# Altium schematic sheet S03_Power_ACDC_RevF.SchDoc
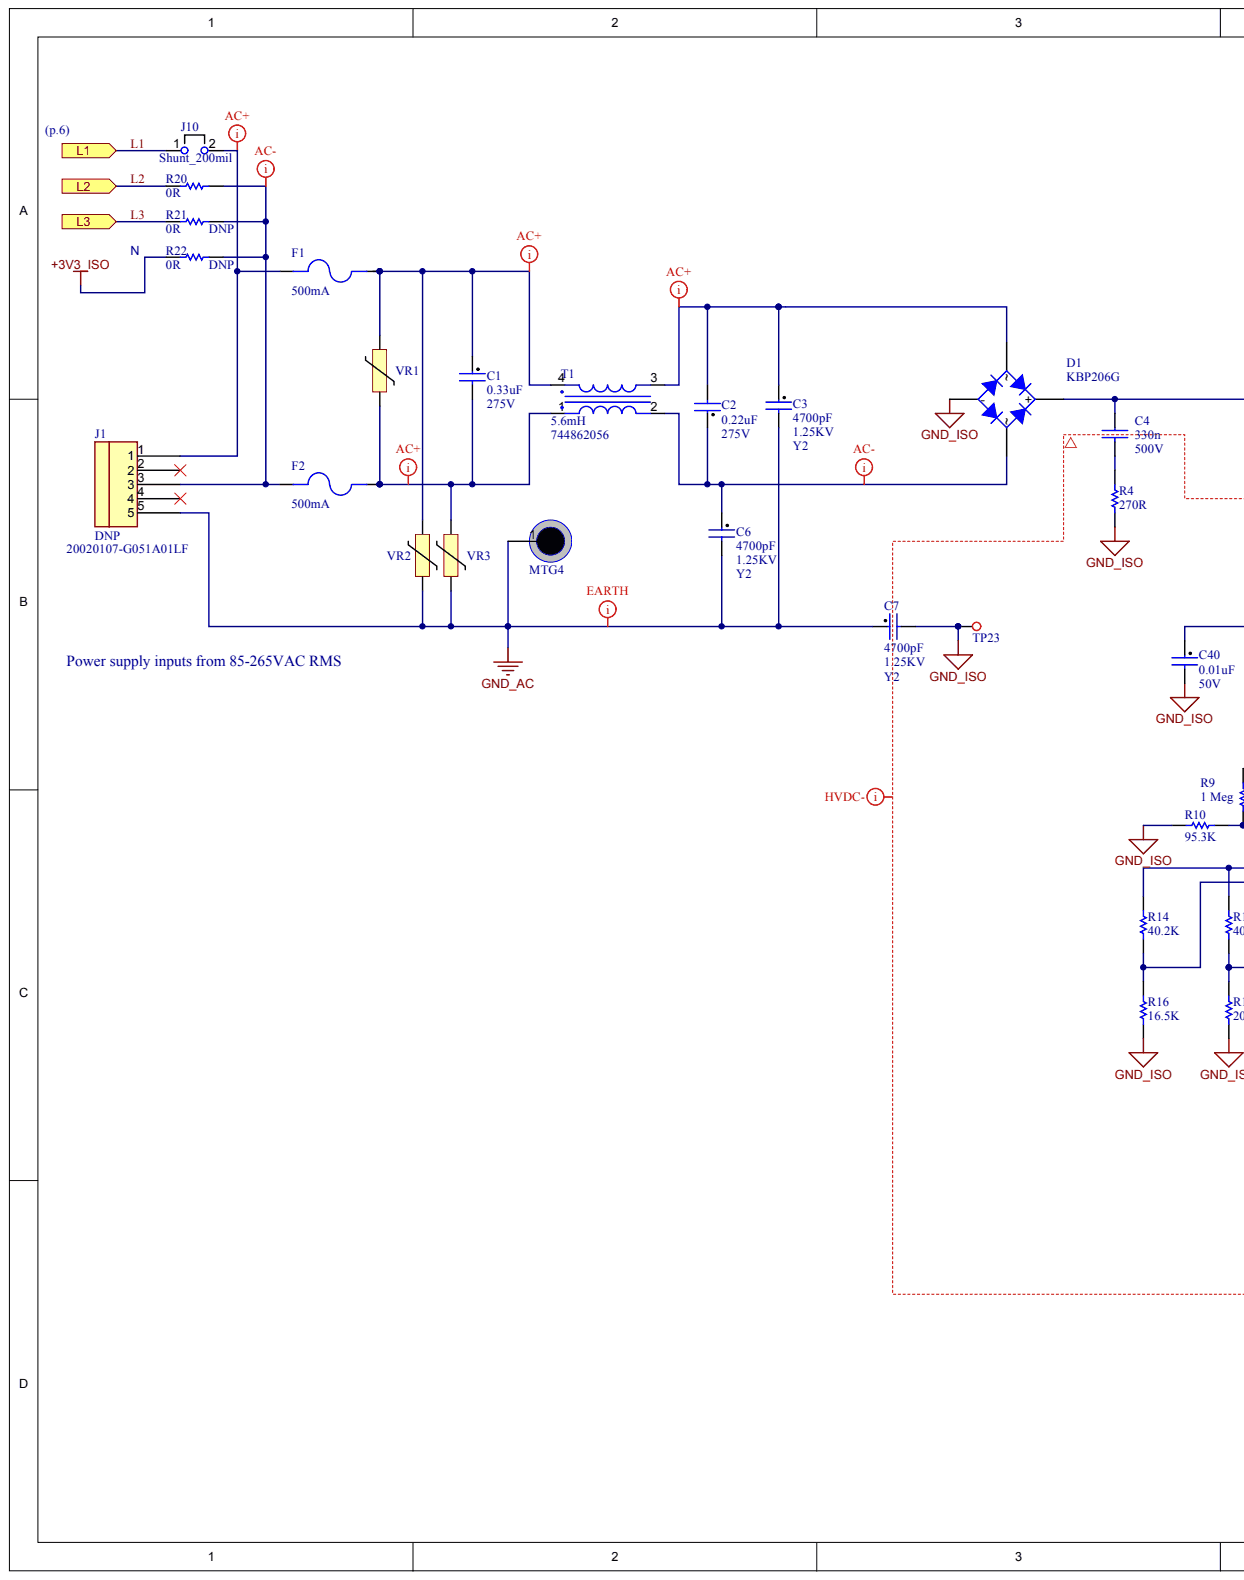
[diagram p0: S03_Power_ACDC_RevF.SchDoc, left]
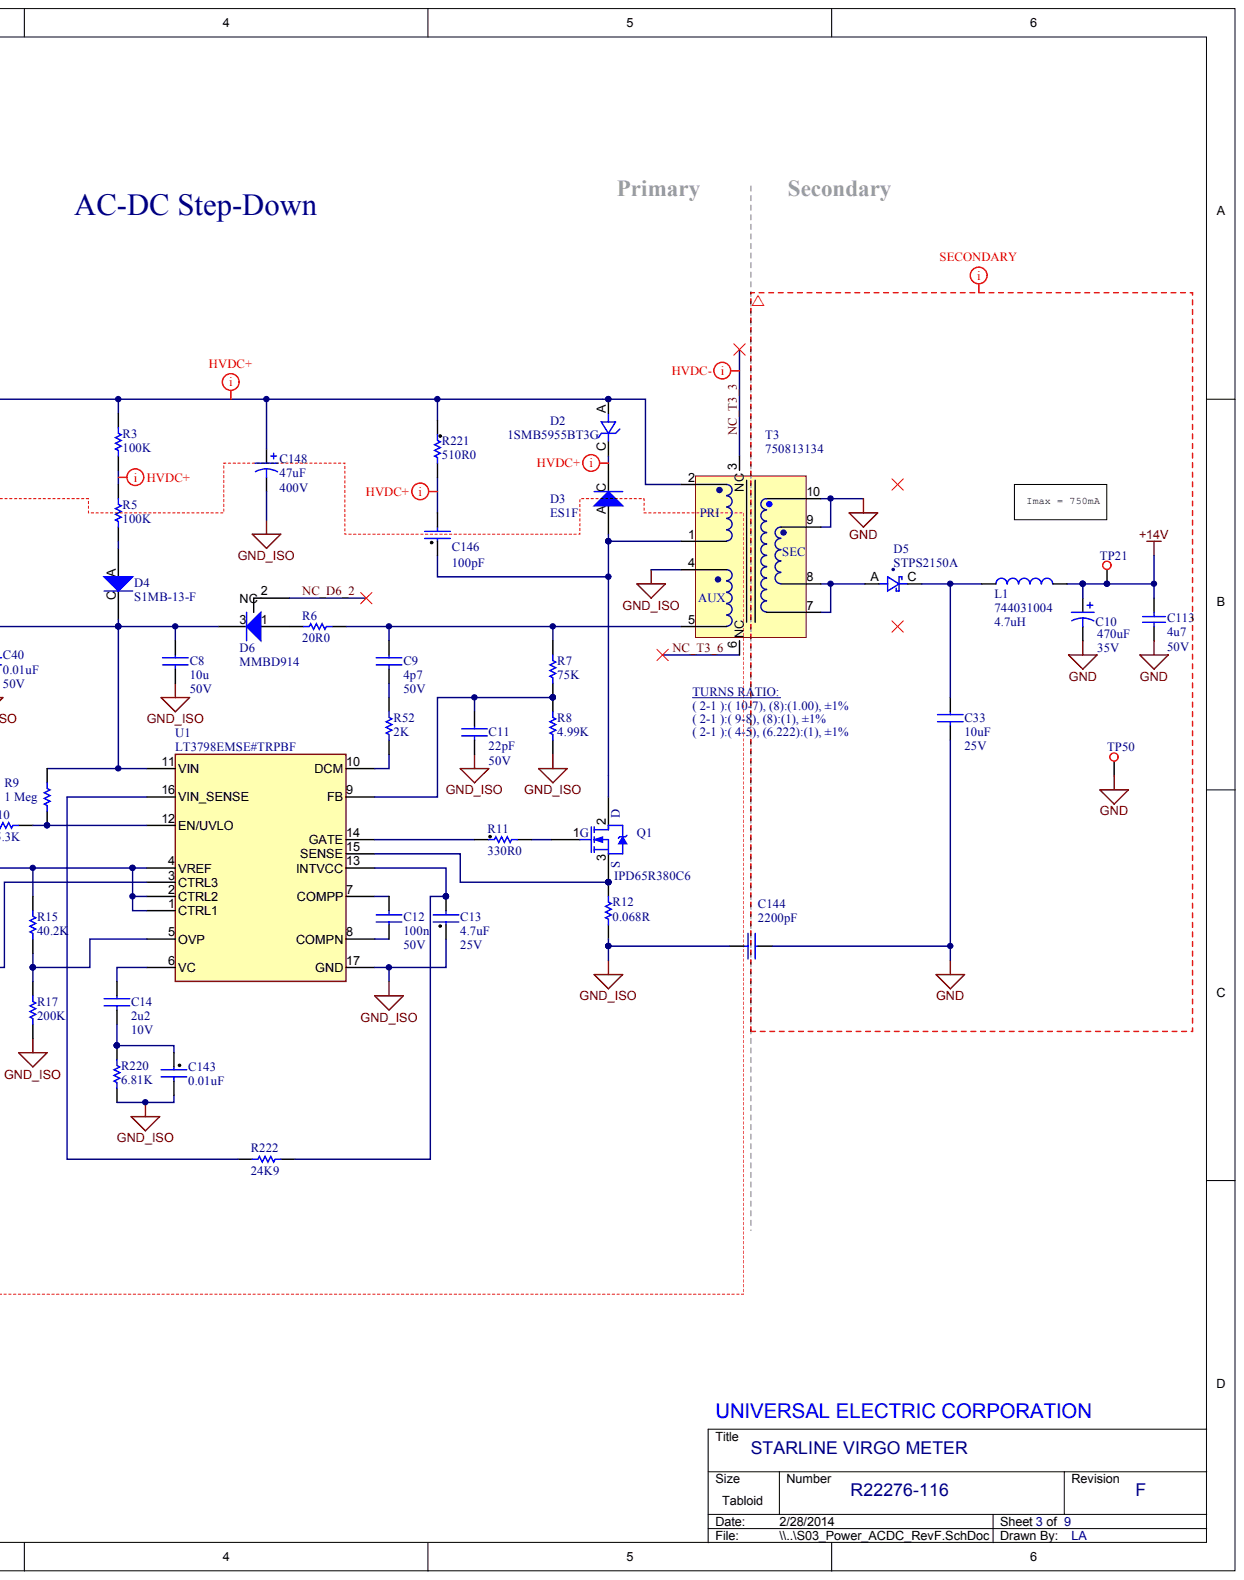
[diagram p1: S03_Power_ACDC_RevF.SchDoc, right]

## Parts
C1  Voltage: 275V  (hidden comment: 0.33uF)
C2  Voltage: 275V  (hidden comment: 0.22uF)
C3  VOLTAGE: 1.25KV  Type: Y2  (hidden comment: 4700pF)
C4  VOLTAGE: 500V  (hidden comment: 330n)
C6  VOLTAGE: 1.25KV  Type: Y2  (hidden comment: 4700pF)
C7  VOLTAGE: 1.25KV  Type: Y2  (hidden comment: 4700pF)
C8  VOLTAGE: 50V  (hidden comment: 10u)
C9  VOLTAGE: 50V  (hidden comment: 4p7)
C10  value 470uF  VOLTAGE: 35V  (hidden comment: NUV_CapPol)
C11  Voltage: 50V  (hidden comment: 22pF)
C12  VOLTAGE: 50V  (hidden comment: 100n)
C13  Voltage: 25V  (hidden comment: 4.7uF)
C14  VOLTAGE: 10V  (hidden comment: 2u2)
C33  Voltage: 25V  (hidden comment: 10uF)
C40  Voltage-Rated: 50V  (hidden comment: 0.01uF)
C113  value 4u7  VOLTAGE: 50V  (hidden comment: NUV_Cap)
C143  0.01uF
C144  2200pF
C146  100pF
C148  value 47uF  VOLTAGE: 400V  (hidden comment: NUV_CapPol)
D1  value KBP206G  (hidden comment: Bridge_Rectifier_KBP)
D2  1SMB5955BT3G
D3  ES1F
D4  S1MB-13-F
D5  STPS2150A
D6  MMBD914
F1  500mA
F2  500mA
J1  NOSTUFF: DNP  (hidden comment: 20020107-G051A01LF)
J10  Shunt_200mil
L1  MFG_PART_NO: 744031004  (hidden comment: 4.7uH)
MTG4  =VALUE
Q1  IPD65R380C6
R3  value 100K  (hidden comment: RES 100K 1/4W 1% SM_1206)
R4  270R
R5  value 100K  (hidden comment: RES 100K 1/4W 1% SM_1206)
R6  value 20R0  (hidden comment: RES 20R0 1/10W 1% 100PPM SM_0603)
R7  value 75K  (hidden comment: RES 75K 1/10W 1% SM_0603)
R8  value 4.99K  (hidden comment: RES 4.99K 1/10W 1% SM_0603)
R9  1 Meg
R10  value 95.3K  (hidden comment: RES 95.3K 1/10W 1% SM_0603)
R11  330R0
R12  0.068R
R14  value 40.2K  (hidden comment: RES 40.2K 1/10W 1% SM_0603)
R15  value 40.2K  (hidden comment: RES 40.2K 1/10W 1% SM_0603)
R16  value 16.5K  (hidden comment: RES 16.5K 1/10W 1% SM_0603)
R17  value 200K  (hidden comment: RES 200K 1/10W 1% SM_0603)
R20  0R
R21  0R
R22  0R
R52  value 2K  (hidden comment: RES 2K 1/10W 1% SM_0603)
R220  value 6.81K  (hidden comment: RES 6.81K 1/10W 1% SM_0603)
R221  510R0
R222  value 24K9  (hidden comment: RES 25K 1/10W 1% SM_0603)
T1  MFG_PART_NO: 744862056  (hidden comment: 5.6mH)
T3  750813134
TP21  =VALUE
TP23  =VALUE
TP50  =VALUE
U1  MFG_PART_NO: LT3798EMSE#TRPBF
VR1  =VALUE
VR2  =VALUE
VR3  =VALUE

## Ports
L1, L2, L3

## Nets
+3V3_ISO: R22.1
+14V: C10.1 C113.2 L1.1 TP21.1
GND: C10.2 C33.2 C113.1 C144.1 T3.9 T3.10 TP50.1
GND_AC: C3.2 C6.2 C7.1 J1.5 MTG4.1 VR2.2 VR3.2
GND_ISO: C7.2 C8.2 C11.2 C13.1 C40.2 C143.2 C144.2 C148.2 D1.4(-) R4.1 R8.1 R10.1 R12.1 R16.1 R17.1 R220.1 T3.4 TP23.1 U1.17(GND)
L1: J10.1 ; port L1
L2: R20.1 ; port L2
L3: R21.1 ; port L3
NC_D6_2: D6.2
NC_T3_3: T3.3(NC)
NC_T3_6: T3.6(NC)
NetC1_1: C1.1 F1.2 T1.4 VR1.1 VR2.1
NetC1_2: C1.2 F2.2 T1.1 VR1.2 VR3.1
NetC2_1: C2.1 C6.1 D1.3(~) T1.2
NetC2_2: C2.2 C3.1 D1.2(~) T1.3
NetC4_1: C4.1 C148.1 D1.1(+) D2.A R3.2 R221.1 T3.2
NetC4_2: C4.2 R4.2
NetC8_1: C8.1 C40.1 D4.C D6.3 R9.2 U1.11(VIN)
NetC9_1: C9.1 R6.2 R7.2 T3.5
NetC9_2: C9.2 R52.2
NetC11_1: C11.1 R7.1 R8.2 U1.9(FB)
NetC12_1: C12.1 U1.8(COMPN)
NetC12_2: C12.2 U1.7(COMPP)
NetC13_2: C13.2 R222.1 U1.13(INTVCC)
NetC14_1: C14.1 U1.6(VC)
NetC14_2: C14.2 C143.1 R220.2
NetC33_1: C33.1 D5.C L1.2
NetC146_1: C146.1 D3.A Q1.2 T3.1
NetC146_2: C146.2 R221.2
NetD2_C: D2.C D3.C
NetD4_A: D4.A R5.1
NetD5_A: D5.A T3.7 T3.8
NetD6_1: D6.1 R6.1
NetF1_1: F1.1 J1.1 J10.2
NetF2_1: F2.1 J1.3 R20.2 R21.2 R22.2
NetQ1_1: Q1.1 R11.2
NetQ1_3: Q1.3 R12.2 U1.15(SENSE)
NetR3_1: R3.1 R5.2
NetR9_1: R9.1 R10.2 U1.12(EN/UVLO)
NetR11_1: R11.1 U1.14(GATE)
NetR14_1: R14.1 R16.2 U1.3(CTRL3)
NetR14_2: R14.2 R15.2 U1.1(CTRL1) U1.2(CTRL2) U1.4(VREF)
NetR15_1: R15.1 R17.2 U1.5(OVP)
NetR52_1: R52.1 U1.10(DCM)
NetR222_2: R222.2 U1.16(VIN_SENSE)
N00012:
N00013:

Not connected: J1 2 4

## Library data of the parts
C1 = (no symbol name): MFG_PART_NO: R46KI333000M1M; MFG: Kemet; Value: 0.33uF
C2 = (no symbol name): MFG_PART_NO: R46KI322000M2M; MFG: Kemet; Value: 0.22uF
C3 C6 C7 = (no symbol name): MFG_PART_NO: PHE850EA4470MA03R17; MFG: Kemet; VALUE: 4700pF
C4 = (no symbol name): MFG_PART_NO: C1812C334KCRACTU; LEVEL: Level_1; MFG: Kemet; TYPE: Capacitor; TOLERANCE: +/- 10%; NUV_DESCRIPTION: CAP CER 0.33UF 500V 10% X7R 1812; NOSTUFF: *; ROOM: *; VALUE: 330n; PACKAGE: SMT; ROHS: RoHS; LAST_UPDATE: 7/6/2012; Created By: K Desrochers
C8 = (no symbol name): MFG_PART_NO: CGA6P3X7S1H106K/SOFT; LEVEL: Level_1; PCBLIB: UEC01.PcbLib; MFG: TDK; TYPE: Capacitor; NUV_DESCRIPTION: CAP CER 10U 50V 10% 1210 SMD; NOSTUFF: *; ROOM: *; VALUE: 10u; PACKAGE: SMT; ROHS: RoHS; Created By: H.Munir
C9 = (no symbol name): MFG_PART_NO: C0402C479D5GACTU; LEVEL: Level_1; PCBLIB: UEC01.PcbLib; MFG: Kemet; TYPE: Capacitor; TOLERANCE: +/- .5pF; NUV_DESCRIPTION: CAP CER 4.7PF 50V NP0 0402; NOSTUFF: *; ROOM: *; VALUE: 4p7; PACKAGE: 0402; ROHS: RoHS; LAST_UPDATE: 7/25/2012; Created By: K Desrochers; Checked By: N.Wennyk
C10 = (no symbol name): MFG_PART_NO: AFK477M35H32T-F; LEVEL: Level_1; PCBLIB: UEC01.PcbLib; MFG: Cornell Dubilier; TYPE: Capacitor; TOLERANCE: +/- 20%; NUV_DESCRIPTION: CAP ALUM 470UF 35V 20% 12.5x13.5; ROOM: *; NOSTUFF: *; PACKAGE: SMT; ROHS: RoHS; Created By: N.Wennyk
C11 = (no symbol name): MFG_PART_NO: CC0402JRNPO9BN220; MFG: Yageo; Value: 22pF
C12 = (no symbol name): MFG_PART_NO: CGA2B3X7R1H104K; LEVEL: Level_1; MFG: TDK; TYPE: Capacitor; TOLERANCE: 10%; NUV_DESCRIPTION: CAP CER 0.1UF 50V 10% X7R 0402; PCBLIB: UEC01.PcbLib; ROOM: *; NOSTUFF: *; VALUE: 100n; PACKAGE: SMT; ROHS: RoHS; LAST_UPDATE: 12/18/2012; Created By: N.Wennyk
C13 = (no symbol name): MFG_PART_NO: GRM21BR61E475KA12L; MFG: Murata; Value: 4.7uF
C14 = (no symbol name): MFG_PART_NO: C1005X5R1A225K; LEVEL: Level_1; PCBLIB: UEC01.PcbLib; MFG: TDK; TYPE: Capacitor; TOLERANCE: +/- 10%; NUV_DESCRIPTION: CAP CER 2.2UF 10V 10% X5R 0402; NOSTUFF: *; ROOM: *; VALUE: 2u2; PACKAGE: SMT; ROHS: RoHS; LAST_UPDATE: 12/18/2012; Created By: N.Wennyk
C33 = (no symbol name): MFG_PART_NO: C3216X7R1E106K; MFG: TDK; Value: 10uF
C40 = (no symbol name): Manufacturer P/N: C1608X7R1H103K080AA; Manufacturer: TDK; Tolerance: 0.05; Maximum Temperature: 125C; Package: SM_0603; Capacitance: 0.01uF
C113 = (no symbol name): MFG_PART_NO: 12105C475KAT2A; SYM_LVL: LEVEL2; LEVEL: Level 1; Commodity Code: ECA; MFG: AVX; TYPE: CER; TOLERANCE: 10%; DIELECTRIC: X7R; NUV_DESCRIPTION: Cap, CER, 4u7, X7R, 10%, 50V, SM_1210; COST_1K: 0.702; ALT_SYM: Yes; ORC_SYM: No; VARIATION: 0; Comments: Updated Dielectric; RESERVED1: J.Schriel; ROOM: *; NOSTUFF: *; LAYOUT_FOOTPRINT: 12105C475KAT2A; PACKAGE: SM_1210; ROHS: RoHS; DXD_SYM: No; ALT_FOOT: No; ALEG_FOOT: No; EXP_FOOT: No; REVISION: A; LAST_UPDATE: 4/23/2009; ComponentLink1URL: Datasheets/cx7r.pdf; ComponentLink1Description: Datasheet; ComponentLink2URL: http://www.avx.com/docs/Catalogs/cx7r.pdf; ComponentLink2Description: Datasheet (web)
C143 = (no symbol name): Manufacturer P/N: GRM155R71E103KA01D; Manufacturer: Murata; Voltage-Rated: 25V; Tolerance: 0.1; Package: SM_0402; Capacitance: 0.01uF
C144 = (no symbol name): MFG_PART_NO: CD12-E2GA222MYNS; MFG: TDK; VALUE: 2200pF
C146 = (no symbol name): Manufacturer P/N: 1812CA101KAT1; Manufacturer: AVX; Voltage-Rated: 600V; Tolerance: 0.1; Maximum Temperature: 125C; Package: SM_1812; Capacitance: 100pF
C148 = (no symbol name): MFG_PART_NO: EEU-ED2G470S; MFG: Panasonic
D1 = (no symbol name): MFG: Diodes Inc.; MFG_PART_NO: KBP206G
D2 = (no symbol name): LEVEL: Level_1; PCBLIB: UEC01.PcbLib; MFG: ON Semi; TYPE: Diode; VOLTAGE: 180V; NUV_DESCRIPTION: DIODE ZENER 180V 3W SMB; MFG_PART_NO: 1SMB5955BT3G; VALUE: 1SMB5955BT3G; PACKAGE: SMT; ROHS: RoHS; LAST_UPDATE: 12/18/2012; Created By: K Desrochers; Checked By: N.Wennyk
D3 = (no symbol name): LEVEL: Level_1; PCBLIB: UEC01.PcbLib; MFG: Fairchild; TYPE: Diode; VOLTAGE: 300V; NUV_DESCRIPTION: DIODE FAST 1A 300V SMA; MFG_PART_NO: ES1F; VALUE: ES1F; PACKAGE: SMT; ROHS: RoHS; LAST_UPDATE: 7/26/2012; Created By: K Desrochers
D4 = (no symbol name): LEVEL: Level_1; PCBLIB: UEC01.PcbLib; MFG: Diodes Inc.; TYPE: Diode; VOLTAGE: 1000V; NUV_DESCRIPTION: RECTIFIER GPP SMD 1000V 1A SMB; MFG_PART_NO: S1MB-13-F; VALUE: S1MB-13-F; PACKAGE: SMT; ROHS: RoHS; LAST_UPDATE: 7/25/2012; Checked By: N.Wennyk; Created By: K Desrochers
D5 = (no symbol name): LEVEL: Level_1; PCBLIB: UEC01.PcbLib; MFG: ST Micro; TYPE: Diode; VOLTAGE: 150V; NUV_DESCRIPTION: DIODE SCHOTTKY 150V 2A SMA; MFG_PART_NO: STPS2150A; VALUE: STPS2150A; PACKAGE: SMT; ROHS: RoHS; LAST_UPDATE: 7/25/2012; Created By: K Desrochers
D6 = (no symbol name): SYM_LVL: LEVEL1; LEVEL: Level_1; MFG: Fairchild; TYPE: Diode; VOLTAGE: 100V; NUV_DESCRIPTION: Diode, Ultrafast, SOT-23; ROOM: *; NOSTUFF: *; MFG_PART_NO: MMBD914; VALUE: MMBD914; PACKAGE: SMT; ROHS: RoHS; Created By: K Desrochers
F1 F2 = (no symbol name): Part Number: 3403.0163; Manufacturer: Schurter Inc.; Manufacturer P/N: 3403.0163; Value: 500mA
J1 = (no symbol name): LEVEL: Level_1; MFG: FCI; NUV_DESCRIPTION: TERM BLOCK 5POS 5.00MM; MFG_PART_NO: 20020107-G051A01LF; TYPE: Connector; VALUE: 20020107-G051A01LF; PACKAGE: Thru-Hole; ROHS: RoHS; LAST_UPDATE: 7/26/2012; Created By: K Desrochers
J10 = (no symbol name): Part Number: HTSW-202-07-F-S; Manufacturer: Samtec; Gender: Male; Spacing: 0.200"; Manufacturer P/N: HTSW-202-07-F-S
L1 = (no symbol name): LEVEL: Level_1; PCBLIB: UEC01.PcbLib; MFG: Wurth; TYPE: Inductor; NUV_DESCRIPTION: INDUCTOR POWER 4.7UH 1.2A SMD; NOSTUFF: *; VALUE: 4.7uH; PACKAGE: SMT; ROHS: RoHS; Created By: N.Wennyk
MTG4 = (no symbol name): SYM_LVL: LEVEL1; LEVEL: Level_1; PCBLIB: UEC01.PcbLib; NUV_DESCRIPTION: Plated Mounting Hole; NOSTUFF: *; ROOM: *; TYPE: Mounting Hole; PACKAGE: Thru-Hole; ROHS: RoHS; Created By: K Desrochers
Q1 = (no symbol name): SYM_LVL: LEVEL1; LEVEL: Level_1; PCBLIB: UEC01.PcbLib; MFG: Infineon; TYPE: IC; NUV_DESCRIPTION: MOSFET N-CH 700V 29A TO252; ROOM: *; NOSTUFF: *; MFG_PART_NO: IPD65R380C6; VALUE: IPD65R380C6; PACKAGE: SMT; ROHS: RoHS; Created By: H.Munir
R3 R5 = (no symbol name): MFG_PART_NO: CRCW1206100KFKEA; MFG: Vishay
R4 = (no symbol name): MFG_PART_NO: ERJ-1TYF271U; SYM_LVL: LEVEL1; LEVEL: Level_1; PCBLIB: UEC01.PcbLib; MFG: Panasonic; TOLERANCE: +/- 1%; NUV_DESCRIPTION: RES 270 OHM 1W 1% 2512 SMD; ROOM: *; NOSTUFF: *; VOLTAGE: =VOLTAGE; VALUE: 270R; TYPE: Resistor; PACKAGE: SMT; ROHS: RoHS; Created By: N.Wennyk
R6 = (no symbol name): MFG_PART_NO: CRCW060320R0FKEA; MFG: Vishay
R7 = (no symbol name): MFG_PART_NO: CRCW060375K0FKEA; MFG: Vishay
R8 = (no symbol name): MFG_PART_NO: CRCW06034K99FKEA; MFG: Vishay
R9 = (no symbol name): MFG_PART_NO: ERJ-3EKF1004V; SYM_LVL: LEVEL1; LEVEL: Level_1; MFG: Panasonic; TOLERANCE: +/- 1%; NUV_DESCRIPTION: RES 1.00M OHM 1/10W 1% 0603 SMD; ROOM: *; NOSTUFF: *; VOLTAGE: =VOLTAGE; VALUE: 1 Meg; TYPE: Resistor; PACKAGE: 0603; ROHS: RoHS; Created By: K Desrochers
R10 = (no symbol name): MFG_PART_NO: CRCW060395K3FKEA; MFG: Vishay
R11 = (no symbol name): MFG_PART_NO: ERJ-P06J331V; Manufacturer: Panasonic; Power: 1/4W; Tolerance: 0.05; RESISTANCE: 330R0; Part Number: ERJ-P06J331V; Package: SMT; Type: Resistor
R12 = (no symbol name): MFG_PART_NO: RL1632R-R068-F; SYM_LVL: LEVEL1; LEVEL: Level_1; PCBLIB: UEC01.PcbLib; MFG: Susumu; TOLERANCE: +/- 1%; NUV_DESCRIPTION: RES .068 OHM 1/2W 1% 1206 SMD; ROOM: *; NOSTUFF: *; VOLTAGE: =VOLTAGE; VALUE: 0.068R; TYPE: Resistor; PACKAGE: SMT; ROHS: RoHS; Created By: N.Wennyk
R14 R15 = (no symbol name): MFG_PART_NO: CRCW060340K2FKEA; MFG: Vishay
R16 = (no symbol name): MFG_PART_NO: CRCW060316K5FKEA; MFG: Vishay
R17 = (no symbol name): MFG_PART_NO: CRCW0603200KFKEA; MFG: Vishay
R20-R22 = (no symbol name): MFG_PART_NO: ZOR-25-B-52-0R; SYM_LVL: LEVEL1; LEVEL: Level_1; PCBLIB: UEC01.PcbLib; MFG: Yageo; TOLERANCE: JUMPER; NUV_DESCRIPTION: RES 0.0 OHM 1/4W TH; ROOM: *; DNP: DNP; VOLTAGE: =VOLTAGE; VALUE: 0R; TYPE: Resistor; PACKAGE: Thru-Hole; ROHS: RoHS; Created By: N.Wennyk
R52 = (no symbol name): MFG_PART_NO: CRCW06032K00FKEA; MFG: Vishay
R220 = (no symbol name): MFG_PART_NO: CRCW06036K81FKEA; MFG: Vishay
R221 = (no symbol name): Manufacturer P/N: ERJ-P14J511U; Manufacturer: Panasonic; Power: 1/2W; Tolerance: 0.05; RESISTANCE: 510R0; Part Number: ERJ-P14J511U; Type: Resistor
R222 = (no symbol name): MFG_PART_NO: CRCW060324K9FKEA; MFG: Vishay
T1 = (no symbol name): LEVEL: Level_1; PCBLIB: UEC01.PcbLib; MFG: Wurth; TYPE: Choke; VOLTAGE: 250V; NUV_DESCRIPTION: COMMON MODE CHOKE 5.6MH 0.6 A T/H; VALUE: 5.6mH; PACKAGE: Thru-Hole; ROHS: RoHS; Created By: H.Munir
T3 = (no symbol name): LEVEL: Level_1; PCBLIB: UEC01.PcbLib; MFG: Wurth; TYPE: transformer; NUV_DESCRIPTION: Flyback Transformer; MFG_PART_NO: 750813134; VALUE: 750813134; PACKAGE: Thru-Hole; ROHS: RoHS; Created By: H.Munir
TP21 TP23 TP50 = (no symbol name): SYM_LVL: LEVEL1; LEVEL: Level_1; NUV_DESCRIPTION: Test Point; ROOM: *; NOSTUFF: NOSTUFF; TYPE: Test Point; PACKAGE: SMT; ROHS: RoHS; LAST_UPDATE: 6/21/2012; Created By: K Desrochers
U1 = (no symbol name): LEVEL: Level_1; MFG: Linear Technology; NUV_DESCRIPTION: IC FLYBACK CTRL W/PFC 16-MSOP; NOSTUFF: *; TYPE: IC; PACKAGE: SMT; ROHS: RoHS; LAST_UPDATE: 6/26/2012; Comment: =VALUE; Created By: K Desrochers
VR1-VR3 = (no symbol name): Part Number: NVR14K431STRF; Manufacturer: Nic Components; Manufacturer P/N: NVR14K431STRF
